# Altium schematic sheet [08] PCIe.SchDoc(BLK4)
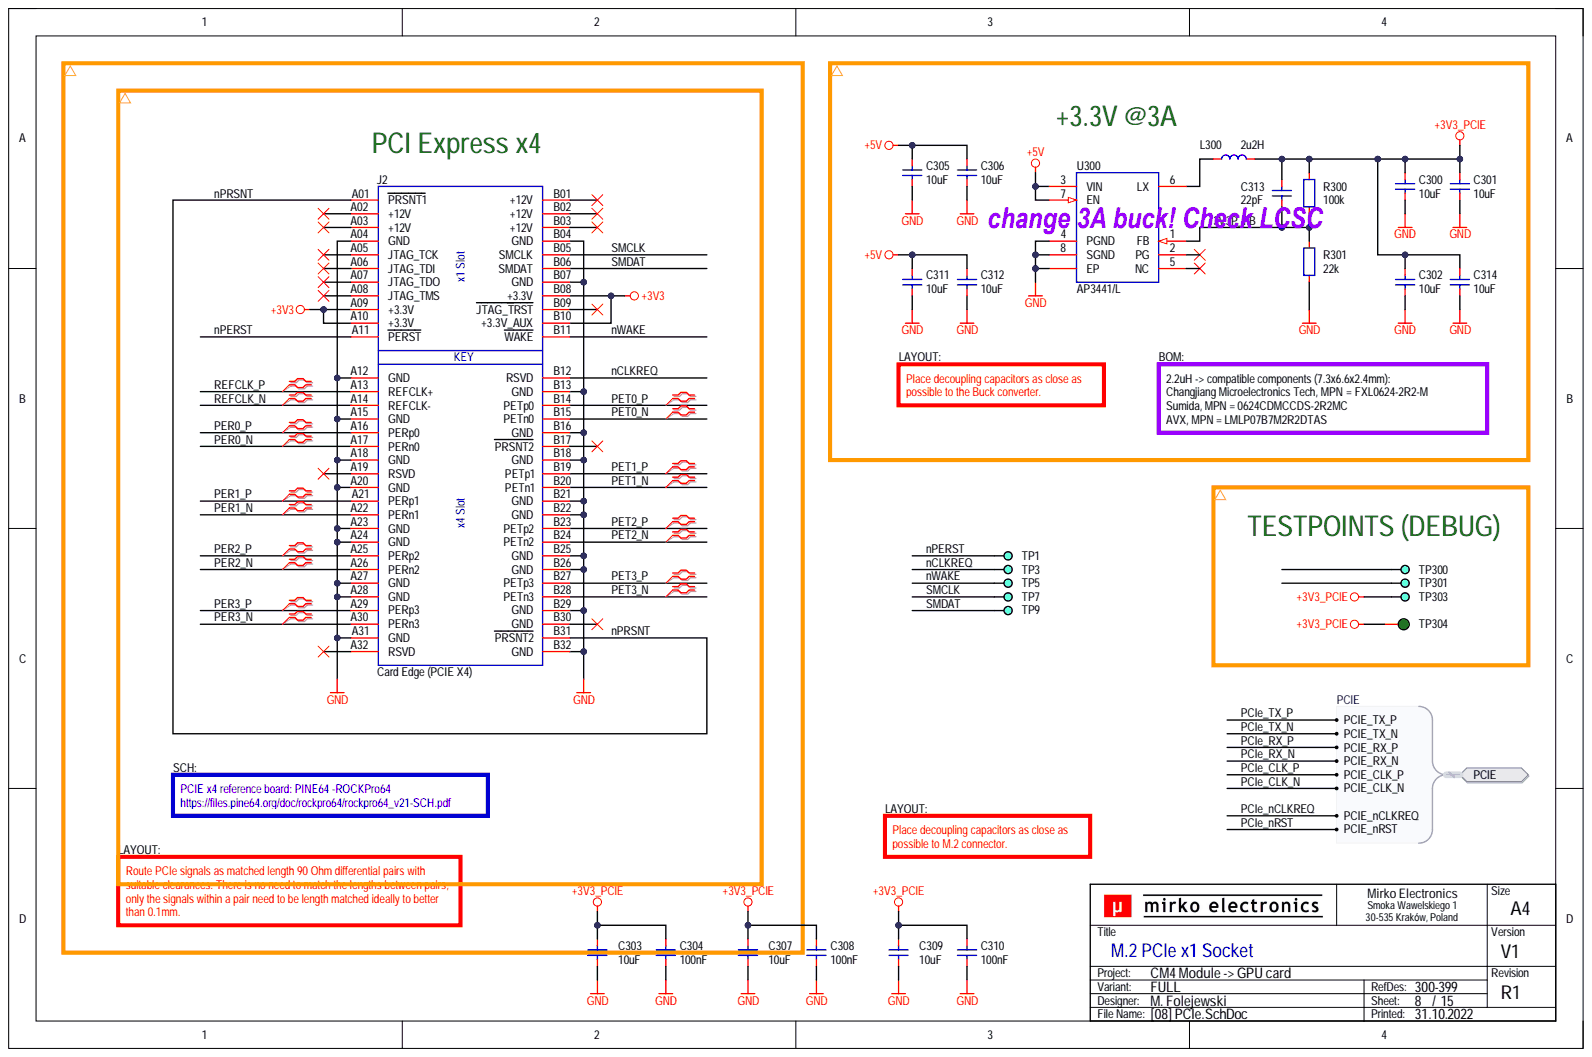
[diagram p0: [08] PCIe.SchDoc]

## Parts
C300  10uF  [CAP_0603_R]
C301  10uF  [CAP_0603_R]
C302  10uF  [CAP_0603_R]
C303  10uF  [CAP_0603_R]
C304  100nF  [CAP_0603_R]
C305  10uF  [CAP_0603_R]
C306  10uF  [CAP_0603_R]
C307  10uF  [CAP_0603_R]
C308  100nF  [CAP_0603_R]
C309  10uF  [CAP_0603_R]
C310  100nF  [CAP_0603_R]
C311  10uF  [CAP_0603_R]
C312  10uF  [CAP_0603_R]
C313  22pF  [CAP_0603_R]
C314  10uF  [CAP_0603_R]
J2  Card Edge (PCIE X4)  [PCB_CARD_EDGE_PCIE_64P]  (no BOM)
L300  2u2H  [IND_SUM_0624CDMCCDS_700X660X240_R]
R300  100k  [RES_0603_R]
R301  22k  [RES_0603_R]
TP1  DEBUG  [PCB_TP_DBG_50]  (value not drawn)  (no BOM)
TP3  DEBUG  [PCB_TP_DBG_50]  (value not drawn)  (no BOM)
TP5  DEBUG  [PCB_TP_DBG_50]  (value not drawn)  (no BOM)
TP7  DEBUG  [PCB_TP_DBG_50]  (value not drawn)  (no BOM)
TP9  DEBUG  [PCB_TP_DBG_50]  (value not drawn)  (no BOM)
TP300  DEBUG  [PCB_TP_DBG_50]  (no BOM)
TP301  DEBUG  [PCB_TP_DBG_50]  (value not drawn)  (no BOM)
TP303  DEBUG  [PCB_TP_DBG_50]  (value not drawn)  (no BOM)
TP304  ICT  [PCB_TP_ICT_100]  (value not drawn)  (no BOM)
U300  AP3441/L  [ICC_DFN8_200X200X90P50_EP_R]

## Ports
PCIE, PCIE.PCIE_CLK_N, PCIE.PCIE_CLK_P, PCIE.PCIE_nCLKREQ, PCIE.PCIE_nRST, PCIE.PCIE_RX_N, PCIE.PCIE_RX_P, PCIE.PCIE_TX_N, PCIE.PCIE_TX_P

## Nets
+5V: C305.2 C306.2 C311.2 C312.2 U300.3(VIN) U300.7(EN)
GND: C300.1 C301.1 C302.1 C303.1 C304.1 C305.1 C306.1 C307.1 C308.1 C309.1 C310.1 C311.1 C312.1 C314.1 J2.A04(GND) J2.A12(GND) J2.A15(GND) J2.A18(GND) J2.A20(GND) J2.A23(GND) J2.A24(GND) J2.A27(GND) J2.A28(GND) J2.A31(GND) J2.B04(GND) J2.B07(GND) J2.B13(GND) J2.B16(GND) J2.B18(GND) J2.B21(GND) J2.B22(GND) J2.B25(GND) J2.B26(GND) J2.B29(GND) J2.B32(GND) R301.1 U300.4(PGND) U300.8(SGND) U300.EP
3V3P_FB: C300.2 C301.2 C302.2 C303.2 C304.2 C307.2 C308.2 C309.2 C310.2 C313.1 C313.2 C314.2 J2.A09(+3.3V) J2.A10(+3.3V) J2.B08(+3.3V) J2.B10(+3.3V_AUX) L300.2 R300.1 R300.2 R301.2 TP303.1 TP304.1 U300.1(FB)
nCLKREQ: J2.B12(RSVD) TP3.1
nPERST: J2.A11(PERST) TP1.1
nPRSNT: J2.A01(PRSNT1) J2.B31(PRSNT2)
nWAKE: J2.B11(WAKE) TP5.1
PER0_N: J2.A17(PERn0)
PER0_P: J2.A16(PERp0)
PER1_N: J2.A22(PERn1)
PER1_P: J2.A21(PERp1)
PER2_N: J2.A26(PERn2)
PER2_P: J2.A25(PERp2)
PER3_N: J2.A30(PERn3)
PER3_P: J2.A29(PERp3)
PET0_N: J2.B15(PETn0)
PET0_P: J2.B14(PETp0)
PET1_N: J2.B20(PETn1)
PET1_P: J2.B19(PETp1)
PET2_N: J2.B24(PETn2)
PET2_P: J2.B23(PETp2)
PET3_N: J2.B28(PETn3)
PET3_P: J2.B27(PETp3)
REFCLK_N: J2.A14(REFCLK-)
REFCLK_P: J2.A13(REFCLK+)
SMCLK: J2.B05(SMCLK) TP7.1
SMDAT: J2.B06(SMDAT) TP9.1
NetL300_1: L300.1 U300.6(LX)
PCIe_CLK_N: ; port PCIE
PCIe_CLK_P: ; port PCIE
PCIe_nCLKREQ: ; port PCIE
PCIe_nRST: ; port PCIE
PCIe_RX_N: ; port PCIE
PCIe_RX_P: ; port PCIE
PCIe_TX_N: ; port PCIE
PCIe_TX_P: ; port PCIE

Not connected: J2 A02(+12V) A03(+12V) A05(JTAG_TCK) A06(JTAG_TDI) A07(JTAG_TDO) A08(JTAG_TMS) A19(RSVD) A32(RSVD) B01(+12V) B02(+12V) B03(+12V) B09(JTAG_TRST) B17(PRSNT2) B30(GND); TP300 1; TP301 1; U300 2(PG) 5(NC)

## Library data of the parts
C300-C303 C305-C307 C309 C311-C314 = CAP-0023: Capacitor; MLCC; GENERIC; TBD; TBD; TBD; TBD; SMD; 0603 (1608);; Database Table Name: CAPACITOR$; Component Kind: Standard; Component Subtype: MLCC; Component Type: Capacitor; Manufacturer: GENERIC; Mounting Type: SMD; MPN: GENERIC; Package (Case): 0603 (1608); Supplier: ?; Library Name: MirFol_db_local.DbLib
  C300-C303 C305-C307 C309 C311 C312 C314: Value: 10uF
  C313: Value: 22pF
C304 C308 C310 = CAP-0008: Capacitor; MLCC; 100nF; TBD; TBD; TBD; TBD; SMD; 0603 (1608);; Database Table Name: CAPACITOR$; Component Kind: Standard; Component Subtype: MLCC; Component Type: Capacitor; Manufacturer: TBD; Mounting Type: SMD; MPN: TBD; Package (Case): 0603 (1608); Supplier: ?; Value: 100nF; Library Name: MirFol_db_local.DbLib
J2 = CON_PCIE_64P_VAR2: Connector; PCIE, x4 (64pin); Library Name: Adapter_X4_Lib.SchLib; Manufacturer: N/A; MPN: N/A; Value: Card Edge (PCIE X4)
L300 = IND: Inductor;; Manufacturer: Changjiang Microelectronics Tech; MPN: FXL0624-2R2-M; Component Subtype: Power Inductor; Package (Case): SMD; Component Kind: Standard; Component Type: Inductor; Mounting Type: SMD; Supplier: ?; Value: 2u2H; Library Name: MirFol_lib.SchLib
R300 = RES-0029: Resistor; Thick Film; 100k; 1%; 100mW; 100PPM; 75V; Standard; SMD; 0603 (1608);; Manufacturer: Yageo Corporation; MPN: TBD; Database Table Name: RESISTOR$; Component Kind: Standard; Component Subtype: Thick Film; Component Type: Resistor; Mounting Type: SMD; Package (Case): 0603 (1608); Supplier: ?; Value: 100k; Library Name: MirFol_db_local.DbLib
R301 = RES-0034: Resistor; Thick Film; 22k; 1%; 100mW; 100PPM; 75V; Standard; SMD; 0603 (1608);; Manufacturer: Yageo Corporation; MPN: TBD; Database Table Name: RESISTOR$; Component Kind: Standard; Component Subtype: Thick Film; Component Type: Resistor; Mounting Type: SMD; Package (Case): 0603 (1608); Supplier: ?; Value: 22k; Library Name: MirFol_db_local.DbLib
TP1 TP3 TP5 TP7 TP9 TP300 TP301 TP303 = PCB_TP_DBG: PCB Marking; Test Point (Debug);; Component Subtype: -; Component Type: Testpoint; Mounting Type: -; Supplier: ?; Value: DEBUG; Library Name: MirFol_lib.SchLib
TP304 = PCB_TP_ICT: Test Point (ICT); Specification: Testpoint (for ICT), SMD pad; Component Type: Testpoint (for ICT); Manufacturer: -; Mounting Type: Non component; MPN: -; Value: ICT; Library Name: MirFol_lib.SchLib
U300 = ICC_DDS_AP3441/L_DFN2020-8: IC; Buck regulator;; Manufacturer: Diodes Incorporated; MPN: AP3441SHE-7B; Specification: Buck converter, 1MHz, 3A, U-DFN2020-8 package; Component Kind: Standard; Component Type: IC; Mounting Type: SMD; Package: U-DFN2020-8; Value: AP3441/L; Library Name: MirFol_lib.SchLib
